# Altium schematic sheet PowerRails.SchDoc
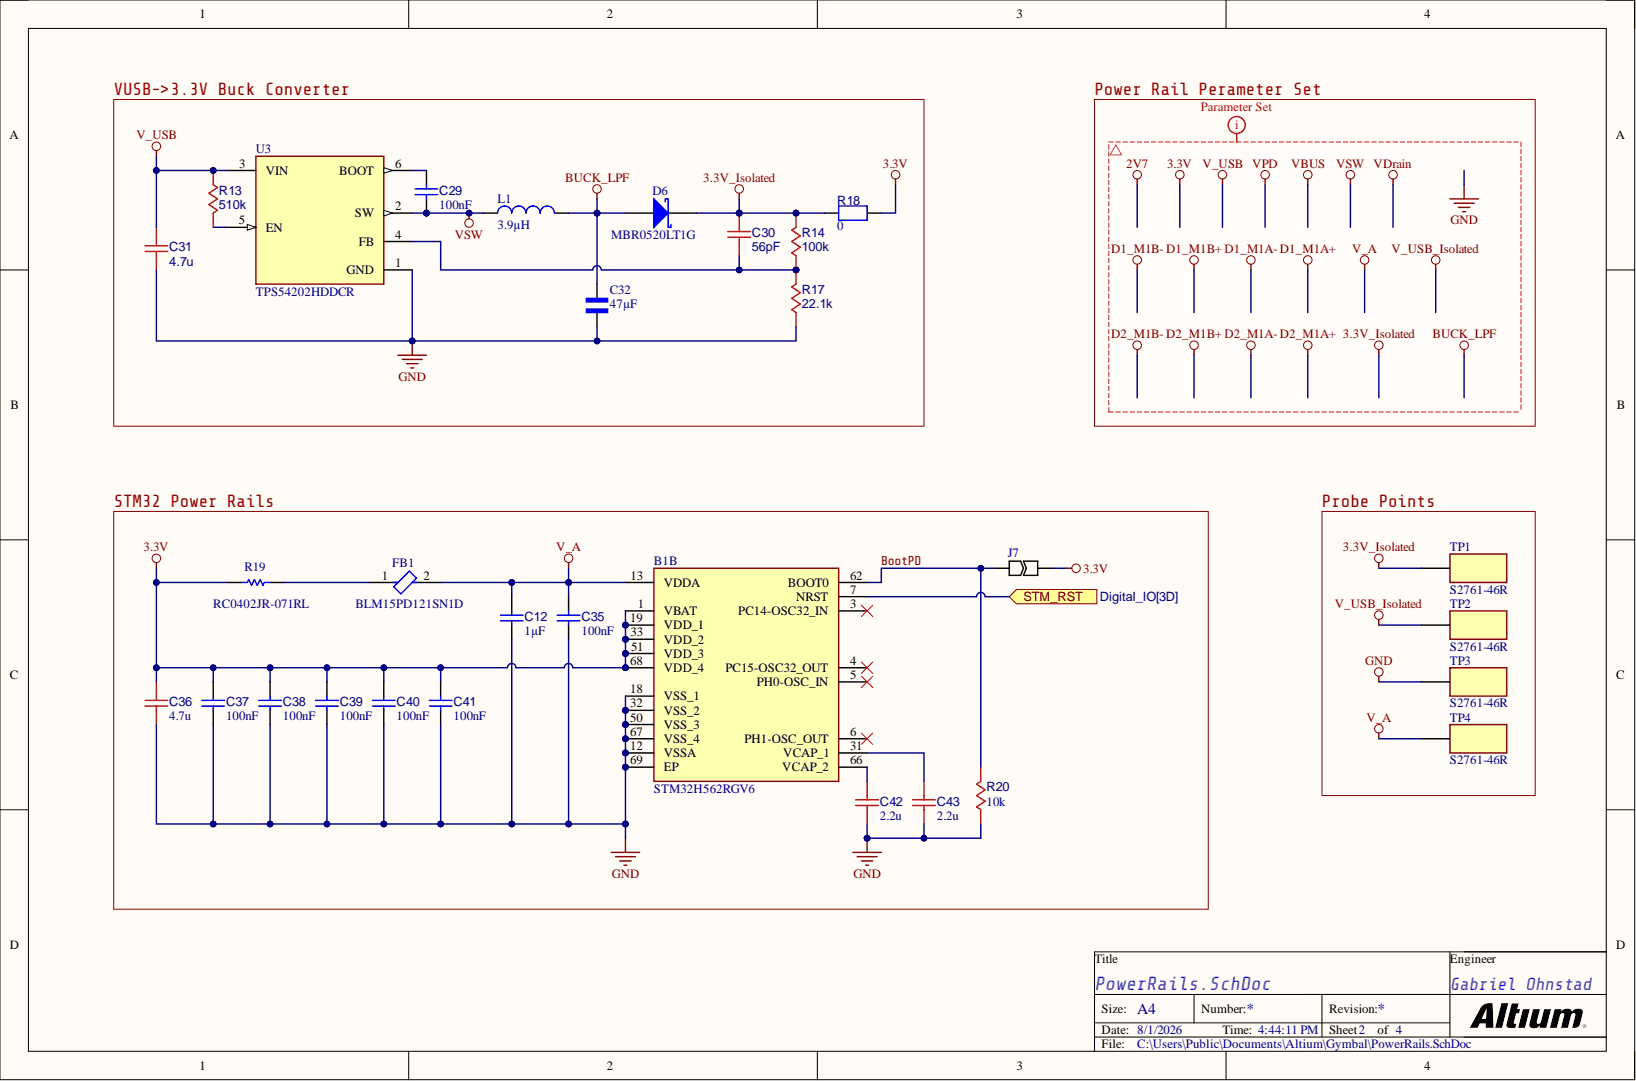
[diagram p0: PowerRails.SchDoc]

## Parts
B1  STM32H562RGV6  [QFN40P800X800X100-69N-D]  (part B)
C12  value 1µF  [CAPC1608X94X35NL15T15]  (hidden comment: Capacitor 1 uF +/- 10% 16 V 0603)
C29  value 100nF  [CAPC0402(1005)60_L]  (hidden comment: Capacitor 100nF +/-20% 25V 0402)
C30  value 56pF  [CAPC0402(1005)60_L]  (hidden comment: Capacitors,ceramic,0402,5%,56pF)
C31  value 4.7u  [CAPC0805(2012)145_L]  (hidden comment: Capacitors,0805,4.7u)
C32  value 47µF  [CAPC3225X270N]  (hidden comment: GRM32ER61C476KE15L)
C35  value 100nF  [CAPC0402(1005)60_L]  (hidden comment: Capacitor 100nF +/-20% 25V 0402)
C36  value 4.7u  [CAPC0805(2012)145_L]  (hidden comment: Capacitors,0805,4.7u)
C37  value 100nF  [CAPC0402(1005)60_L]  (hidden comment: Capacitor 100nF +/-20% 25V 0402)
C38  value 100nF  [CAPC0402(1005)60_L]  (hidden comment: Capacitor 100nF +/-20% 25V 0402)
C39  value 100nF  [CAPC0402(1005)60_L]  (hidden comment: Capacitor 100nF +/-20% 25V 0402)
C40  value 100nF  [CAPC0402(1005)60_L]  (hidden comment: Capacitor 100nF +/-20% 25V 0402)
C41  value 100nF  [CAPC0402(1005)60_L]  (hidden comment: Capacitor 100nF +/-20% 25V 0402)
C42  value 2.2u  [CAPC0805(2012)145_L]  (hidden comment: Capacitors,0805,2.2u)
C43  value 2.2u  [CAPC0805(2012)145_L]  (hidden comment: Capacitors,0805,2.2u)
D6  MBR0520LT1G  [ONSC-SOD-123-2-425-04_V]
FB1  BLM15PD121SN1D  [FP-BLM15-0_05-IPC_B]
J7  JUMPER  [JUMPER]  (value not drawn)
L1  value 3.9µH  [SRR5018-3R9Y-Footprint-1]  (hidden comment: SRR5018-3R9Y)
R13  value 510k  [RESC0402(1005)_L]  (hidden comment: Resistors,0402,510k)
R14  value 100k  [RESC0402(1005)_L]  (hidden comment: Resistors,0402,100k)
R17  value 22.1k  [RESC0402(1005)_L]  (hidden comment: Resistors,0402,22.1k)
R18  value 0  [RESC0805(2012)_L]  (hidden comment: HCJ0805ZT0R00)
R19  RC0402JR-071RL  [RESC1005X40X25LL05T10]
R20  value 10k  [RESC0402(1005)_L]  (hidden comment: Resistors,0402,10k)
TP1  S2761-46R  [HARWIN_S2761-46R]
TP2  S2761-46R  [HARWIN_S2761-46R]
TP3  S2761-46R  [HARWIN_S2761-46R]
TP4  S2761-46R  [HARWIN_S2761-46R]
U3  TPS54202HDDCR  [FP-DDC0006A-IPC_C]

## Ports
STM_RST

## Nets
3.3V: B1.1(VBAT) B1.19(VDD_1) B1.33(VDD_2) B1.51(VDD_3) B1.68(VDD_4) C36.2 C37.1 C38.1 C39.1 C40.1 C41.1 J7.2 R18.1 R19.1
3.3V_Isolated: C30.2 D6.2 R14.2 R18.2 TP1.1
BUCK_LPF: C32.1 D6.1 L1.2
GND: B1.12(VSSA) B1.18(VSS_1) B1.32(VSS_2) B1.50(VSS_3) B1.67(VSS_4) B1.69(EP) C12.1 C31.1 C32.2 C35.2 C36.1 C37.2 C38.2 C39.2 C40.2 C41.2 C42.1 C43.1 R17.1 R20.1 TP3.1 U3.1(GND)
V_A: B1.13(VDDA) C12.2 C35.1 FB1.2 TP4.1
V_USB: C31.2 R13.1 U3.3(VIN)
V_USB_Isolated: TP2.1
VSW: C29.1 L1.1 U3.2(SW)
BootPD: B1.62(BOOT0) J7.1 R20.2
STM_RST: B1.7(NRST) ; port STM_RST
NetB1_31: B1.31(VCAP_1) C43.2
NetB1_66: B1.66(VCAP_2) C42.2
NetC29_2: C29.2 U3.6(BOOT)
NetC30_1: C30.1 R14.1 R17.2 U3.4(FB)
NetFB1_1: FB1.1 R19.2
NetR13_2: R13.2 U3.5(EN)
2V7:
D1_M1A+:
D1_M1A-:
D1_M1B+:
D1_M1B-:
D2_M1A+:
D2_M1A-:
D2_M1B+:
D2_M1B-:
VBUS:
VDrain:
VPD:

Not connected: B1 3(PC14-OSC32_IN) 4(PC15-OSC32_OUT) 5(PH0-OSC_IN) 6(PH1-OSC_OUT)

## Library data of the parts
B1 = CMP-004-000001-1: MCU 32-bit ARM Cortex M33 RISC 1MB Flash 1.8V/2.5V/3.3V 68-Pin VFQFPN EP Tray; Manufacturer_Name: STMicroelectronics; Manufacturer_Part_Number: STM32H563RGV6; Core Architecture: ARM Cortex-M33; Geometry.Height: 1mm; China RoHS: Compliant; Component Kind: Standard; Datasheet Link: https://www.st.com/resource/en/datasheet/stm32h562ag.pdf; Design Item ID: STM32H563RGV6; Lifecycle Status: Production; Memory Format: FLASH; Mouser Part Number: 511-STM32H563RGV6; Mouser Price/Stock: https://www.mouser.co.uk/ProductDetail/STMicroelectronics/STM32H563RGV6?qs=Jm2GQyTW%2FbjkEO3Um3%252BxKg%3D%3D; Pins: 68; REACH SVHC: Yes; RoHS: Compliant; Source: STM32H563RGV6.SchLib; Library Name: Gabriel A Ohnstad
C12 = CMP-001-00003-9: Chip Capacitor, 1 uF, +/- 10%, 16 V, 0603 (1608 Metric); Capacitor Type: Ceramic; Voltage Rating: 16V; Case/Package: 0603; Component Kind: Standard; Max Operating Temperature: 125°C; Min Operating Temperature: -55°C; Mounting Technology: SM; Pins: 2; RoHS Compliant: True; Tolerance: 10%; Library Name: Gabriel A Ohnstad
C29 C35 C37-C41 = CMP-001-00065-7: Chip Capacitor, 100nF +/-20%, 25V, 0402, Thickness 0.6 mm; Tolerance: 10%; Case/Package: 0402; Component Kind: Standard; Max Operating Temperature: 85°C; Min Operating Temperature: -55°C; Mounting Technology: SMT; Pins: 2; Voltage Rating: 25V; Library Name: Gabriel A Ohnstad
C30 = Capacitors: Capacitor, Filter; Capacitor Type: ceramic; Case/Package: 0402; Component Kind: Standard; Component Type: Capacitors; Tolerance: 5%; Library Name: Gabriel A Ohnstad
C31 C36 = Capacitors: Capacitor, Decoupling; Case/Package: 0805; Component Kind: Standard; Component Type: Capacitors; Library Name: Gabriel A Ohnstad
C32 = CMP-001-00087-1: SMD Capacitor X5R(EIA) with Capacitance: 47uF Tol. 10%. Rated Voltage: 16Vdc; MF: Murata Electronics; Case/Package: 3225 Murata; Check_prices: https://www.snapeda.com/parts/GRM32ER61C476KE15L/Murata+Electronics+North+America/view-part/?ref=eda; Component Kind: Standard; Component Type: Standard; Design Item ID: GRM32ER61C476KE15L; MP: GRM32ER61C476KE15L; Pins: 2; SnapEDA_Link: https://www.snapeda.com/parts/GRM32ER61C476KE15L/Murata+Electronics+North+America/view-part/?ref=snap; Source: GRM32ER61C476KE15L.SchLib; Library Name: Gabriel A Ohnstad
C42 C43 = Capacitors: Capacitor, Charge Pump; Case/Package: 0805; Component Kind: Standard; Component Type: Capacitors; Library Name: Gabriel A Ohnstad
D6 = CMP-004-00003-2: Schottky Power Rectifier, 20 V, 5.5 A, -65 to 125 degC, 2-Pin SMD, RoHS, Tape and Reel; Manufacturer: ON Semiconductor; Elements: 1; Forward Current: 500mA; Forward Voltage: 385mV; Max Surge Current: 5.5A; Peak Reverse Current: 250uA; Case/Package: SOD; Component Kind: Standard; Datasheet URL: http://www.onsemi.cn/pub_link/Collateral/MBR0520LT1-D.PDF; Datasheet Version: Rev. 6, 01/2012; Manufacturer URL: http://www.onsemi.com/; Max Operating Temperature: 125°C; Min Operating Temperature: -65°C; Mounting Technology: SM; Package Description: 2-Pin Surface Mount Device, Body 2.69 x 1.6 mm; Package Quantity: 3000; Package Reference: SOD-123-2-425-04; Packaging: TapeandReel; Pins: 2; RoHS Compliant: True; Library Name: Gabriel A Ohnstad
FB1 = CMP-0686-00281-4: Chip Ferrite Bead, 0402, 120Ω @ 100MHz, 0.09Ω, 25%, 1.3A; DC Resistance (DCR): 90mR; Impedance: 120R; Material: Steel; Number of Circuits: 1; Case Code (Imperial): 0402; Case Code (Metric): 1005; Case/Package: 0402; Component Kind: Standard; Core Material: Ferrite; Current Rating: 1.3A; DC Current: 1.3A; Depth: 0.55mm; Height: 0.5mm; Lead Free: Lead Free; Length: 1mm; Library Name: Altium Content Vault; Max Current Rating: 1.3A; Max DC Current: 1.3A; Max Operating Temperature: 125°C; Military Standard: Not; Min Operating Temperature: -55°C; Number of Lines: 1; Number of Pins: 2; Package Quantity: 1; Packaging: Tape and Reel; Radiation Hardening: No; REACH SVHC: No SVHC; Termination: SMD/SMT; Test Frequency: 100MHz; Tolerance: 25%; Width: 0.5mm
J7 = CMP-009-00061-10: Component Kind: Standard; Description: JUMPER; Pins: 2; Library Name: Gabriel A Ohnstad
L1 = CMP-006-00022-2: Inductor Power Shielded Wirewound 3.9uH 30% 100KHz 8Q-Factor Ferrite 2A 0.055Ohm DCR T/R; DC Resistance (DCR): 55mΩ; Max Current Rating: 2.1A; Number of Circuits: 1; Q Factor: 8; Self Resonant Frequency: 64MHz; Case Code (Imperial): 2323; Case Code (Metric): 5858; Case/Package: 2323; China RoHS: Compliant; Component Kind: Standard; Composition: Wirewound; Core Material: Ferrite; Country of Origin: Mainland China; Current Rating: 2A; DC Current: 2A; Depth: 6.1mm; Export Control Classification Number (ECCN) Code: EAR99; Harmonized Tariff Schedule (HTS) Code: 8504.50.80.00; Height: 1.8mm; Height - Seated (Max): 1.8034mm; Introduction Date: 2007-08-29; Length: 5.8mm; Lifecycle Status: Production; Max DC Current: 2A; Max Operating Temperature: 125°C; Military Standard: Not; Min Operating Temperature: -40°C; Mounting Technology: Surface Mount; Number of Terminals: 2; Packaging: Tape and Reel; REACH SVHC: Yes; RoHS: Compliant; Saturation Current: 2.1A; Schedule B: 8504500000, 8504500000; Shielding: Shielded; Termination: SMD/SMT; Test Frequency: 100kHz; Tolerance: 30%; Width: 5.8mm; Library Name: Gabriel A Ohnstad
R13 R20 = Resistors: Resistor, Bootstrap; Case/Package: 0402; Component Kind: Standard; Component Type: Resistors; Library Name: Gabriel A Ohnstad
R14 R17 = Resistors: Resistor; Case/Package: 0402; Component Kind: Standard; Component Type: Resistors; Library Name: Gabriel A Ohnstad
R18 = CMP-009-00179-2: HCJ Series 0805 Jumper Surface Mount Chip Resistor; Manufacturer Package Identifier: 0805 (2012 metric); DNP: TRUE; Power Rating: 500mW; Case Code (Imperial): 0805; Case Code (Metric): 2012; Case/Package: 0805; Component Kind: Standard; Composition: Metal Strip; Country of Origin: Taiwan; Export Control Classification Number (ECCN) Code: EAR99; Harmonized Tariff Schedule (HTS) Code: 8533.21.00.30; Height: 650µm; Introduction Date: 2013-09-03; Lead Free: Lead Free; Length: 2mm; Lifecycle Status: Production; Max Operating Temperature: 155°C; Min Operating Temperature: -55°C; Mounting Technology: Surface Mount; Number of Terminals: 2; Number of Terminations: 2; Packaging: Cut Tape (CT); Radiation Hardening: No; Ratings: AEC-Q200; REACH SVHC: Yes; RoHS: Compliant; Schedule B: 8533210045, 8533210045; Termination: Wraparound; Width: 1.2mm; Library Name: Gabriel A Ohnstad
R19 = CMP-2002-07953-1: Manufacturer: Yageo / Phycomp; Component Kind: Standard; Library Name: Altium Content Vault; Part Number: RC0402JR-071RL
TP1-TP4 = CMP-028-000000-3: MF: {'manufacturer': u'Harwin Inc.'}; Availability: Unavailable; Component Kind: Standard; Component Type: Standard; Design Item ID: S2761-46R; MP: S2761-46R; Package: None; Pin Count: 1; Price: None; Source: S2761-46R.SchLib; Library Name: Gabriel A Ohnstad
U3 = CMP-019-00052-1: 4.5V to 28V Input, 2A Output, Synchronous Step-Down Voltage Converter 6-SOT-23-THIN -40 to 125; Manufacturer Lifecycle Status: ACTIVE; Max Duty Cycle: 100%; Max Junction Temperature (Tj): 125°C; Nominal Supply Current: 45µA; Number of Outputs: 1; Quiescent Current: 40µA; Switching Frequency: 500kHz; Topology: Buck; Component Kind: Standard; Height: 1.1mm; Lead Free: Lead Free; Length: 2.9mm; Lifecycle Status: Production; Max Operating Temperature: 125°C; Max Supply Voltage: 28V; Min Operating Temperature: -40°C; Min Supply Voltage: 4.5V; Output Current: 2A; Pins: 6; RoHS: Compliant; Schedule B: 8542390000, 8542390000; Thickness: 870µm; Width: 1.6mm; Library Name: Gabriel A Ohnstad
